# Altium schematic sheet SchematicActuatorSubsystem.SchDoc
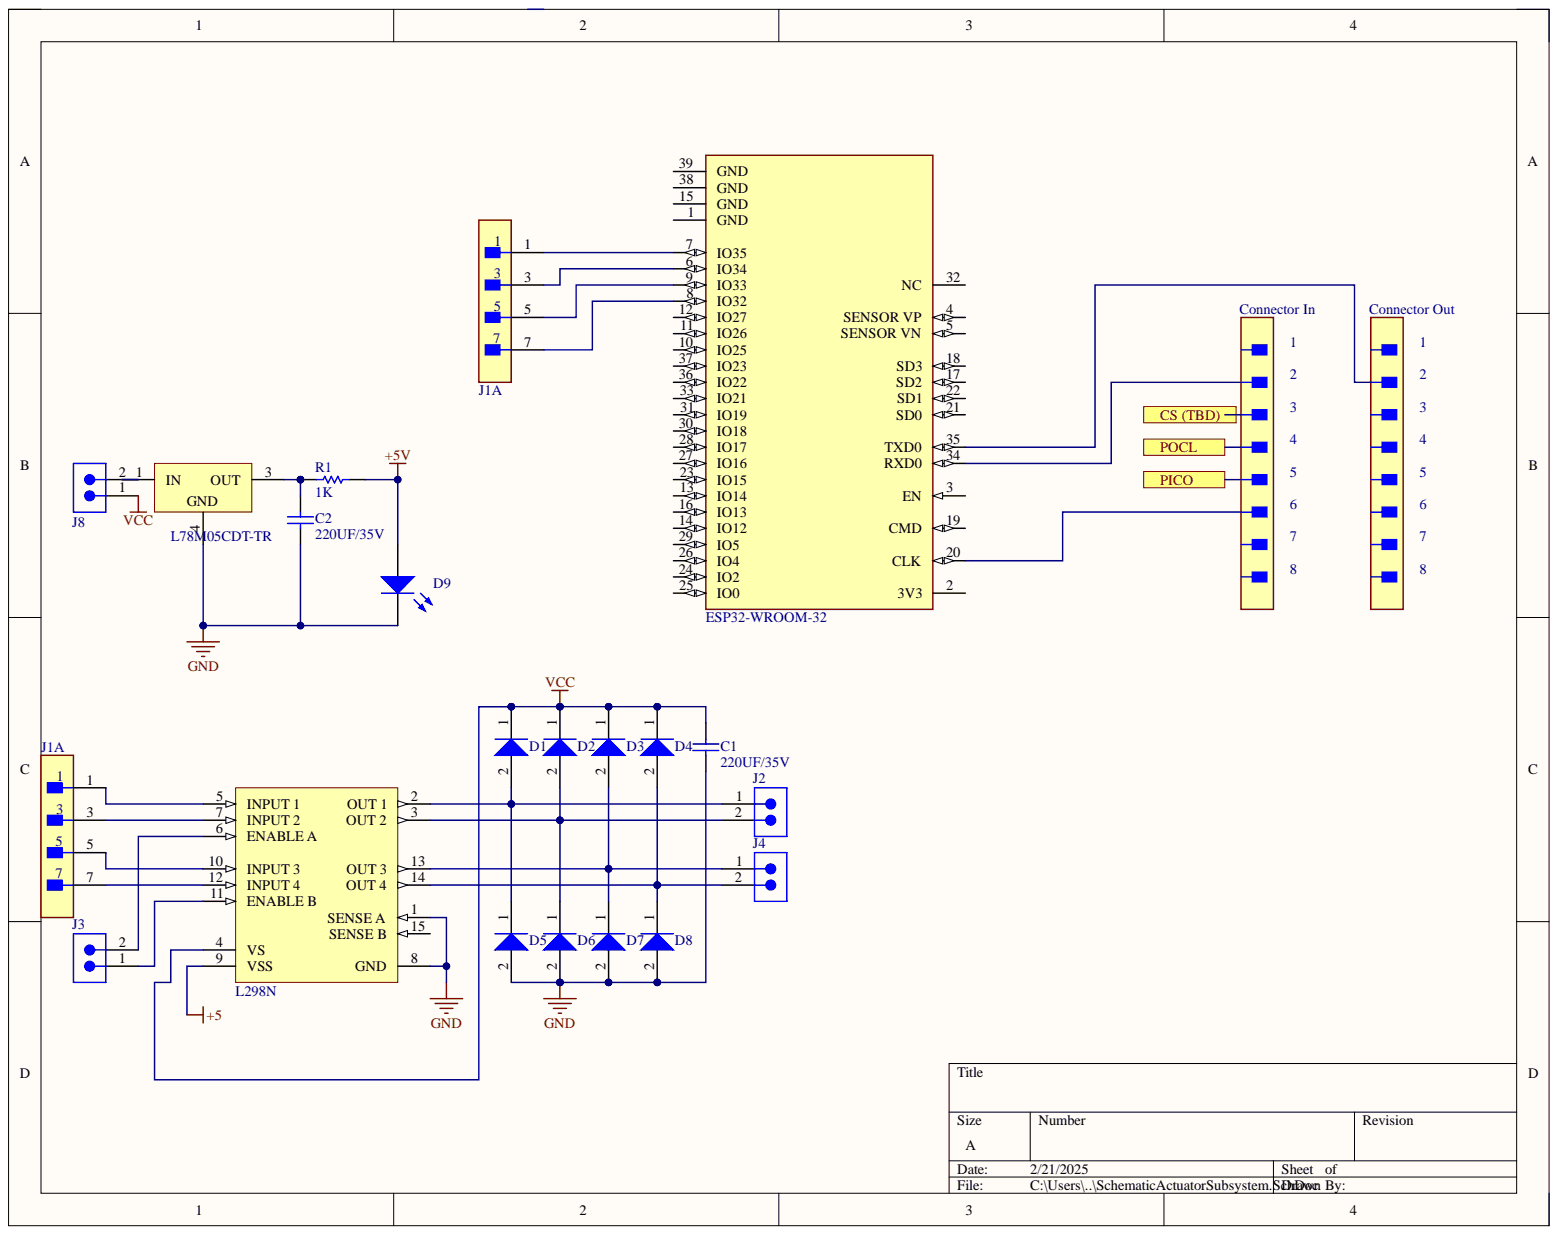
[diagram p0: SchematicActuatorSubsystem.SchDoc]

## Parts
C1  value 220UF/35V  (hidden comment: Capacitor)
C2  value 220UF/35V  (hidden comment: Capacitor)
Connector_In  39-01-2081  [FP-39-01-2081-MFG]  (value not drawn)
Connector_Out  39-01-2081  [FP-39-01-2081-MFG]  (value not drawn)
D1  BAT54HT1G  [ONSC-SOD-323-2-477-02_V]  (value not drawn)
D2  BAT54HT1G  [ONSC-SOD-323-2-477-02_V]  (value not drawn)
D3  BAT54HT1G  [ONSC-SOD-323-2-477-02_V]  (value not drawn)
D4  BAT54HT1G  [ONSC-SOD-323-2-477-02_V]  (value not drawn)
D5  BAT54HT1G  [ONSC-SOD-323-2-477-02_V]  (value not drawn)
D6  BAT54HT1G  [ONSC-SOD-323-2-477-02_V]  (value not drawn)
D7  BAT54HT1G  [ONSC-SOD-323-2-477-02_V]  (value not drawn)
D8  BAT54HT1G  [ONSC-SOD-323-2-477-02_V]  (value not drawn)
D9  Light-Emitting Diode  (value not drawn)
J1  87831-0841  [FP-87831-0841-MFG]  (value not drawn)  (part A)
J1  87831-0841  [FP-87831-0841-MFG]  (value not drawn)  (part A)
J2  620302124022  [620302124022]  (value not drawn)
J3  620302124022  [620302124022]  (value not drawn)
J4  620302124022  [620302124022]  (value not drawn)
J8  620302124022  [620302124022]  (value not drawn)
MD?  ESP32-WROOM-32  [FP-ESP32-WROOM-32-MFG]
R1  value 1K  (hidden comment: Resistor)
U?  L78M05CDT-TR  [TO-252_L]
  L298N  [STM-FM-15]

## Ports
CS (TBD), PICO, POCL

## Nets
+5: .9(VSS)
+5V: D9.1 R1.2
GND: C1.2 C2.1 D5.2 D6.2 D7.2 D8.2 D9.2 U?.4 .1(SENSE_A) .8(GND)
Net_2: D1.2 D5.1 J2.1 .2(OUT_1)
Net_3: D2.2 D6.1 J2.2 .3(OUT_2)
Net_5: J1.1 .5(INPUT_1)
Net_6: J3.2 .6(ENABLE_A)
Net_7: J1.3 .7(INPUT_2)
Net_10: J1.5 .10(INPUT_3)
Net_11: J3.1 .11(ENABLE_B)
Net_12: J1.7 .12(INPUT_4)
Net_13: D3.2 D7.1 J4.1 .13(OUT_3)
Net_14: D4.2 D8.1 J4.2 .14(OUT_4)
Net_15: .15(SENSE_B)
VCC: C1.1 D1.1 D2.1 D3.1 D4.1 J8.1 .4(VS)
NetC2_2: C2.2 R1.1 U?.3
NetJ1_1: J1.1 MD?.7(IO35)
NetJ1_3: J1.3 MD?.6(IO34)
NetJ1_5: J1.5 MD?.9(IO33)
NetJ1_7: J1.7 MD?.8(IO32)
NetJ8_2: J8.2 U?.1
N00001: ; port CS (TBD)
N00002: ; port PICO
N00003: ; port POCL

Not connected: MD? 1(GND) 2(3V3) 3(EN) 4(SENSOR_VP) 5(SENSOR_VN) 10(IO25) 11(IO26) 12(IO27) 13(IO14) 14(IO12) 15(GND) 16(IO13) 17(SD2) 18(SD3) 19(CMD) 20(CLK) 21(SD0) 22(SD1) 23(IO15) 24(IO2) 25(IO0) 26(IO4) 27(IO16) 28(IO17) 29(IO5) 30(IO18) 31(IO19) 32(NC) 33(IO21) 34(RXD0) 35(TXD0) 36(IO22) 37(IO23) 38(GND) 39(GND)

## Library data of the parts
C1 C2 = Capacitor: SimulationGenericComponent: True; Component Kind: Standard; Description: Capacitor; Library Name: Simulation Generic Components.IntLib; Simulation: C_Capacitor_Default
Connector_In Connector_Out = CMP-04776-000197-1: CONN PLUG 8POS PANEL MOUNT; Max Voltage Rating (AC): 600V; Number of Positions: 8; Pitch: 4.2mm; Row Spacing: 0.165inch; Color: Natural; Component Kind: Standard; Connector Type: Plug; Contact Type: Male Pin; Depth: 23.9mm; Fastening Type: Ramp; Housing Color: Natural; Housing Material: Nylon; Length: 23.4mm; Library Name: Altium Content Vault; Max Operating Temperature: 105°C; Max Voltage Rating (DC): 600V; Min Operating Temperature: -40°C; Mount: Panel; Number of Rows: 2; Orientation: Straight; Packaging: Bag; Radiation Hardening: No; Series: Mini-Fit® Jr™ 5559; Termination: Crimp
D1-D8 = CMP-1055-00462-1: Schottky Barrier Diode, 2-Pin SOD-323, Pb-Free, Tape and Reel; Manufacturer: On Semiconductor; Configuration: Single; IFSM Max (A): 0.6; trr Max (ns): 5; Component Kind: Standard; ComponentLink1Description: Manufacturer URL; ComponentLink1URL: http://www.onsemi.com/; ComponentLink2Description: Datasheet; ComponentLink2URL: http://www.onsemi.cn/pub_link/Collateral/BAT54HT1-D.PDF; ComponentLink3Description: Package Specification; ComponentLink3URL: http://www.onsemi.com/pub_link/Collateral/477-02.PDF.1; DatasheetVersion: Rev. 6; Library Name: Altium Content Vault; Mounting Technology: Surface Mount; PackageDescription: 2-Pin SOD, Body 1.7 x 1.25 mm; PackageReference: SOD-323-2-477-02; PackageVersion: Rev. H, 03/2007; Packing: Tape and Reel; PartNumber: BAT54HT1G; RoHS: TRUE
D9 = LED: AF: 1; BV: 0; BV_MAX: 1e99; EG: 1.11; FC: 0.5; IBV: 0.001; IS: 1e-14; MJSW: 0.33; NTUN: 30; SimulationGenericComponent: True; TNOM: 27; XTI: 3; CJO: 0; CJP: 0; Component Kind: Standard; CTA: 0; CTP: 0; Description: Light-Emitting Diode; FCS: 0.5; FV_MAX: 1e99; IKF: 0; IKR: 0; ISR: 1e-14; JSW: 0; JTUN: 0; JTUNSW: 0; KEG: 1; KF: 0; LEVEL: 1; Library Name: Simulation Generic Components.IntLib; N: 1; NBV: 1; NR: 1; NS: 1; PHP: 1; RS: 0; Simulation: diode; TCV: 0; TLEV: 0; TLEVC: 0; TM1: 0; TM2: 0; TPB: 0; TPHP: 0; TRS: 0; TRS2: 0; TT: 0; TTT1: 0; TTT2: 0; VJ: 1; XTITUN: 3
J1 J1 = CMP-04776-000334-1: CONN HEADER VERT 8POS 2MM; Current Rating: 2A; Glow Wire Compliant: Non-compliant; Number of Circuits: 8; Voltage Rating: 125V; Component Kind: Standard; Contact Material: Brass; Contact Plating: Gold; Housing Material: Thermoplastic; Library Name: Altium Content Vault; Max Operating Temperature: 105°C; Min Operating Temperature: -55°C; Mount: Through Hole; Number of Contacts: 8; Number of Positions: 8; Number of Rows: 2; Orientation: Vertical; Package Quantity: 4000; Packaging: Rail/Tube; Pitch: 2mm; Radiation Hardening: No; REACH SVHC: No SVHC; Series: 87831; Termination: Solder
J2-J4 J8 = CMP-1502-00660-2: NexarPartId: 18818242; Connector Type: Male Pin; Contact Resistance: 20mΩ; Current Rating: 2A; Gender: Male; Insulation: Insulated; Insulation Resistance: 1GΩ; Number of Contacts: 2; Operating Supply Voltage: 100V; Pitch: 2mm; Voltage Rating: 125V; Color: Beige, White; Component Kind: Standard; Contact Gender: Male; Contact Material: Copper; Contact Mating Length: 3.5052mm; Contact Plating: Tin; Flammability Rating: UL94 V-0; Height: 6.6mm; Housing Material: Nylon; Lead Pitch: 2mm; Length: 5mm; Library Name: Altium Content Vault; Max Operating Temperature: 85°C; Min Operating Temperature: -40°C; Mount: Surface Mount; Number of Pins: 2; Number of Positions: 2; Number of Rows: 1; Orientation: Vertical; Packaging: Tape and Reel; REACH SVHC: Unknown; RoHS: Compliant; Termination: SMD/SMT; Width: 7.9mm
MD? = CMP-194065-000008-1: RX TXRX MOD WIFI SURFACE MOUNT; Data Rate: 150Mbps; Frequency: 2.5GHz; Operating Supply Voltage: 3.6V; Sensitivity: -98dBm; Case/Package: SMD/SMT; Component Kind: Standard; Library Name: Altium Content Vault; Max Operating Temperature: 85°C; Min Operating Temperature: -40°C; Mount: Surface Mount; Package Quantity: 550; Packaging: Cut Tape; Weight: 0.088185oz
R1 = Resistor: SimulationGenericComponent: True; TC1: 0; Component Kind: Standard; Description: Resistor; Library Name: Simulation Generic Components.IntLib; Simulation: R_Resistor_Default; TC2: 0
U? = CMP-0244-00178-1: Positive Voltage Regulator, 5V, 3-Pin DPAK, Tape and Reel; Component Kind: Standard; ComponentLink1Description: Manufacturer URL; ComponentLink1URL: http://www.st.com; ComponentLink2Description: Datasheet; ComponentLink2URL: http://www.st.com/internet/com/TECHNICAL_RESOURCES/TECHNICAL_LITERATURE/DATASHEET/CD00000447.pdf; DatasheetVersion: 1/11/2010; Library Name: Altium Content Vault; PackageDescription: 3-Pin Transistor Outline Package 2.28 mm Pitch, 9.7 mm Lead Span (DPAK); PackageReference: TO-252; PackageVersion: 2011
 = CMP-2000-06526-1: Dual Full-Bridge Driver, 4.8 to 46 V, -40 to 150 degC, 15-Pin FM (MW 15L), RoHS, Tube; Manufacturer: STMicroelectronics; PartNumber: L298; Driver Case Style: Multiwatt; Motor Type: FullBridge; No. of Outputs: 4Outputs; No. of Pins: 15Pins; Output Current: 2A; Output Voltage: 46V; Supply Voltage Max: 7V; Supply Voltage Min: 4.5V; SVHC: NoSVHC(17-Dec-2015); Automotive Qualification Standard: -; Component Kind: Standard; Datasheet URL: https://datasheet.ciiva.com/15109/getdatasheetpartid-50682-15109332.pdf; Datasheet Version: 01/2000; Library Name: Altium Content Vault; Manufacturer URL: http://www.st.com/; Mounting Technology: ThroughHole; MSL: -; Operating Temperature Max: 130°C; Operating Temperature Min: -25°C; Package Description: 15-Pin Flange Mount, Body 5 x 19.6 mm, Pitch 1.27 mm; Package Reference: FM-15; Packaging: Each; Product Range: -
